# Altium schematic sheet usb_c.SchDoc
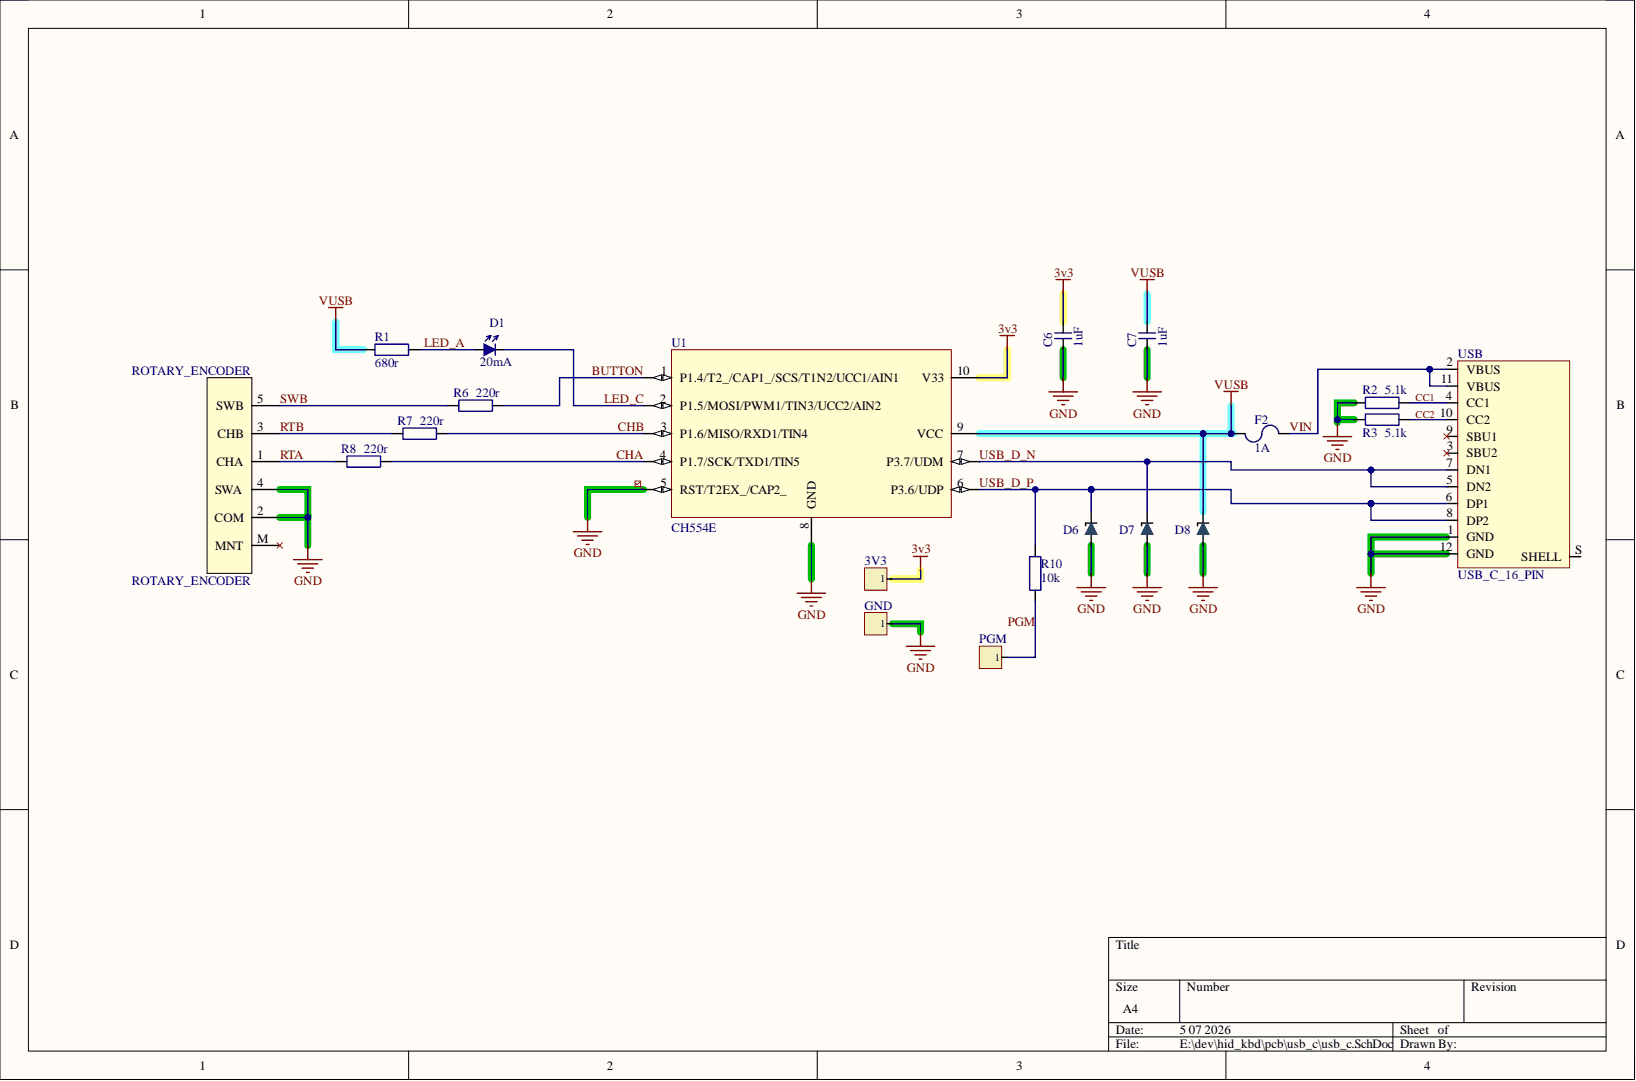
[diagram p0: usb_c.SchDoc]

## Parts
3V3  CON2  [CON2]  (value not drawn)  (no BOM)
C6  1uF  [MLCC_0603]
C7  1uF  [MLCC_0603]
D1  20mA  [SMT_LED_0603]
D6  TVS_DIODE  [TVS_DIODE]  (value not drawn)
D7  TVS_DIODE  [TVS_DIODE]  (value not drawn)
D8  TVS_DIODE  [TVS_DIODE]  (value not drawn)
F2  1A  [FUSE 0603_1608 0.5MM]
GND  CON2  [CON2]  (value not drawn)  (no BOM)
PGM  CON2  [CON2]  (value not drawn)  (no BOM)
R1  680r  [SMT_RES_0603]
R2  5.1k  [SMT_RES_0603]
R3  5.1k  [SMT_RES_0603]
R6  220r  [SMT_RES_0603]
R7  220r  [SMT_RES_0603]
R8  220r  [SMT_RES_0603]
R10  10k  [SMT_RES_0603]
ROTARY_ENCODER  ROTARY_ENCODER  [ENCODER]  (no BOM)
U1  CH554E  [MSOP10]
USB  USB_C_16_PIN  [USB_C_16PIN]

## Nets
3v3: 3V3.1 C6.2 U1.10(V33)
GND: C6.1 C7.1 D6.2 D7.2 D8.2 GND.1 R2.2 R3.2 ROTARY_ENCODER.2(COM) ROTARY_ENCODER.4(SWA) U1.5(RST/T2EX_/CAP2_) U1.8(GND) USB.1(GND) USB.12(GND)
VUSB: C7.2 D8.1 F2.2 R1.1 U1.9(VCC)
BUTTON: R6.2 U1.1(P1.4/T2_/CAP1_/SCS/T1N2/UCC1/AIN1)
CC1: R2.1 USB.4(CC1)
CC2: R3.1 USB.10(CC2)
CHA: R8.2 U1.4(P1.7/SCK/TXD1/TIN5)
CHB: R7.2 U1.3(P1.6/MISO/RXD1/TIN4)
LED_A: D1.2 R1.2
LED_C: D1.1 U1.2(P1.5/MOSI/PWM1/TIN3/UCC2/AIN2)
PGM: PGM.1 R10.1
RTA: R8.1 ROTARY_ENCODER.1(CHA)
RTB: R7.1 ROTARY_ENCODER.3(CHB)
SWB: R6.1 ROTARY_ENCODER.5(SWB)
USB_D_N: D7.1 U1.7(P3.7/UDM) USB.5(DN2) USB.7(DN1)
USB_D_P: D6.1 R10.2 U1.6(P3.6/UDP) USB.6(DP1) USB.8(DP2)
VIN: F2.1 USB.2(VBUS) USB.11(VBUS)

Not connected: ROTARY_ENCODER M(MNT); USB 3(SBU2) 9(SBU1) S(SHELL)

## Library data of the parts
C6 C7 = CAP_CERAMIC: MLCC; Component Kind: Standard; JLCPCB Part #: C29936; Value: 1uF; Library Name: wch554e.SchLib
D1 = LED: Component Kind: Standard; Description: LED; JLCPCB Part #: C205445; Value: 20mA; Library Name: wch554e.SchLib
D6-D8 = TVS_DIODE: TVS Diode; Component Kind: Standard; JLCPCB Part #: C151980; Library Name: wch554e.SchLib
F2 = FUSE: Resettable fuse; Component Kind: Standard; JLCPCB Part #: C210357; Value: 1A; Library Name: wch554e.SchLib
R1-R3 R6-R8 R10 = RESISTOR: Component Kind: Standard; Description: Resistor; Library Name: wch554e.SchLib
  R1: JLCPCB Part #: C23228; Value: 680r
  R2 R3: JLCPCB Part #: C25804; Value: 5.1k
  R6-R8: JLCPCB Part #: C27592; Value: 220r
  R10: JLCPCB Part #: C25804; Value: 10k
ROTARY_ENCODER = ROTARY_ENCODER: Rotary Encoder; Library Name: wch554e.SchLib
USB = USB_C_16_PIN: USB Receptacle TYPE-C 16PIN 2MD; Component Kind: Standard; JLCPCB Part #: C2765186; Library Name: wch554e.SchLib
U1 = CH554E: Component Kind: Standard; Description: CH554E; JLCPCB Part #: C111293; Library Name: wch554e.SchLib
3V3 GND PGM = CON2: Library Name: wch554e.SchLib
